# Altium schematic sheet telemetry_adc.SchDoc
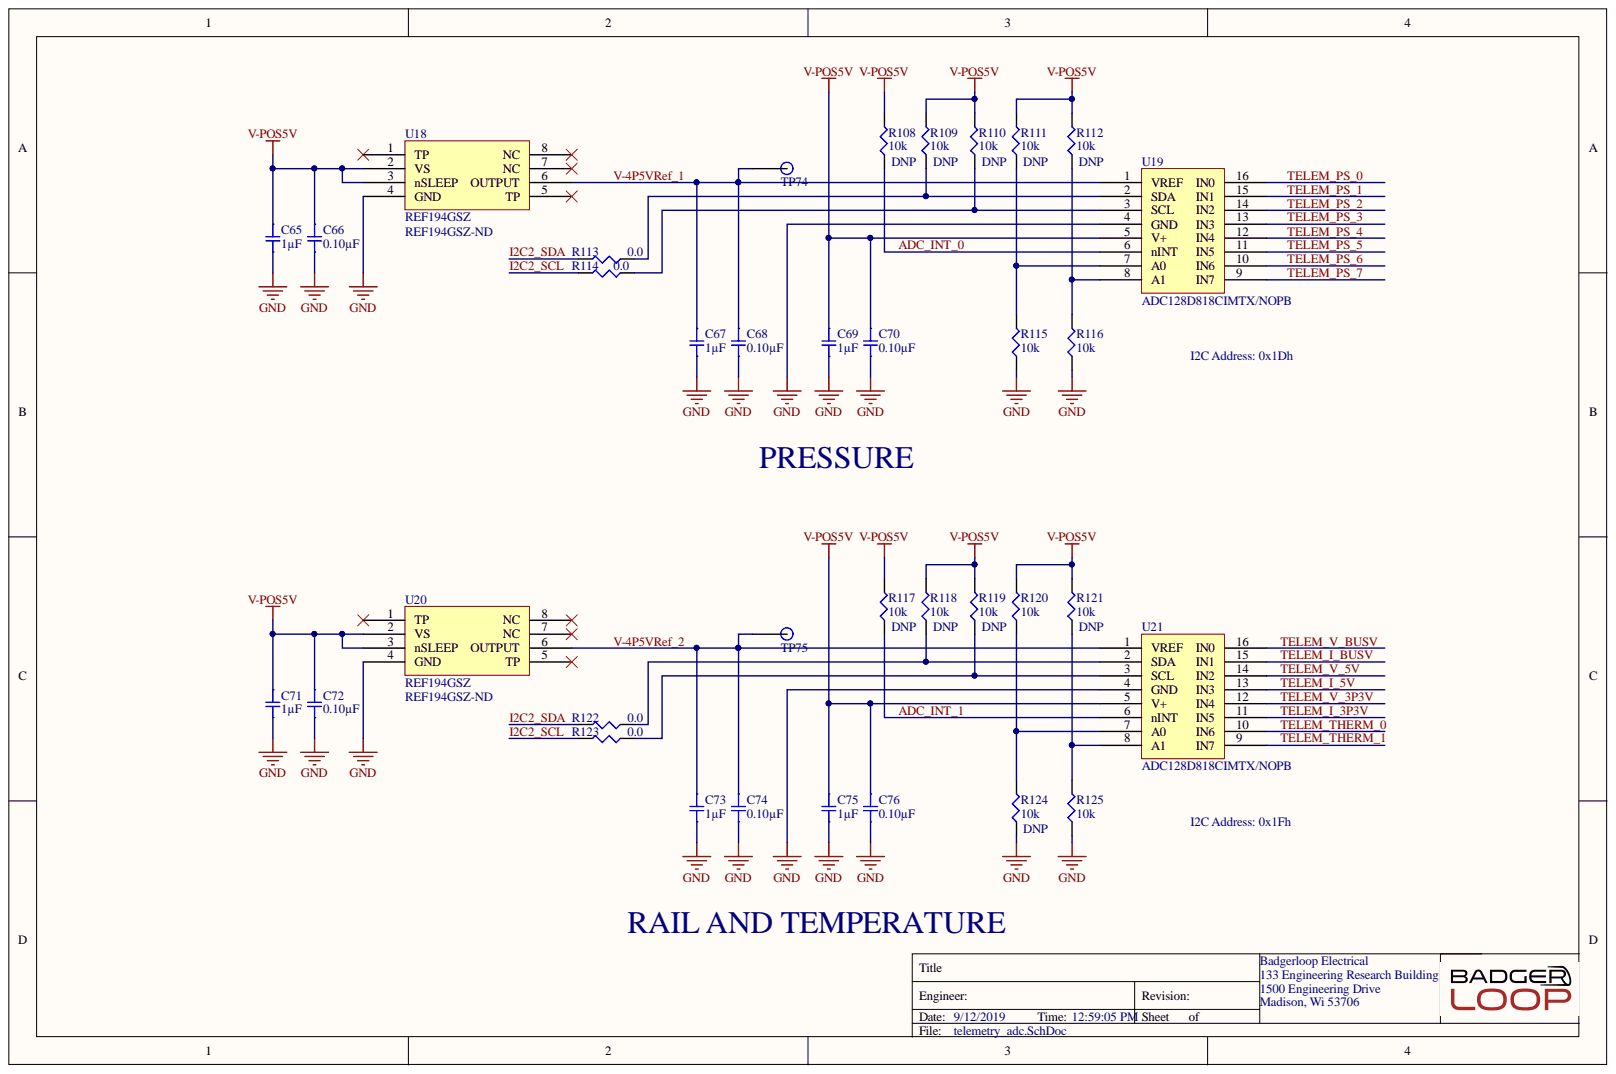
[diagram p0: telemetry_adc.SchDoc]

## Parts
C65  1µF  [SMD-0805C]
C66  0.10µF  [SMD-0805C]
C67  1µF  [SMD-0805C]
C68  0.10µF  [SMD-0805C]
C69  1µF  [SMD-0805C]
C70  0.10µF  [SMD-0805C]
C71  1µF  [SMD-0805C]
C72  0.10µF  [SMD-0805C]
C73  1µF  [SMD-0805C]
C74  0.10µF  [SMD-0805C]
C75  1µF  [SMD-0805C]
C76  0.10µF  [SMD-0805C]
R108  10k  [SMD-0805-RES]
R109  10k  [SMD-0805-RES]
R110  10k  [SMD-0805-RES]
R111  10k  [SMD-0805-RES]
R112  10k  [SMD-0805-RES]
R113  0.0  [SMD-0805-RES]
R114  0.0  [SMD-0805-RES]
R115  10k  [SMD-0805-RES]
R116  10k  [SMD-0805-RES]
R117  10k  [SMD-0805-RES]
R118  10k  [SMD-0805-RES]
R119  10k  [SMD-0805-RES]
R120  10k  [SMD-0805-RES]
R121  10k  [SMD-0805-RES]
R122  0.0  [SMD-0805-RES]
R123  0.0  [SMD-0805-RES]
R124  10k  [SMD-0805-RES]
R125  10k  [SMD-0805-RES]
TP74  TP  [Test Point]
TP75  TP  [Test Point]
U18  REF194GSZ  Supplier Part Number 1: REF194GSZ-ND  [SOP127P600X175-8N]
U19  ADC128D818CIMTX/NOPB
U20  REF194GSZ  Supplier Part Number 1: REF194GSZ-ND  [SOP127P600X175-8N]
U21  ADC128D818CIMTX/NOPB

## Nets
GND: C65.2 C66.2 C67.2 C68.2 C69.2 C70.2 C71.2 C72.2 C73.2 C74.2 C75.2 C76.2 R115.1 R116.1 R124.1 R125.1 U18.4(GND) U19.4(GND) U20.4(GND) U21.4(GND)
V-POS5V: C65.1 C66.1 C69.1 C70.1 C71.1 C72.1 C75.1 C76.1 R108.2 R109.2 R110.2 R111.2 R112.2 R117.2 R118.2 R119.2 R120.2 R121.2 U18.2(VS) U18.3(nSLEEP) U19.5(V+) U20.2(VS) U20.3(nSLEEP) U21.5(V+)
ADC_INT_0: R108.1 U19.6(nINT)
ADC_INT_1: R117.1 U21.6(nINT)
I2C2_SCL: R114.2 R123.2
I2C2_SDA: R113.2 R122.2
TELEM_I_3P3V: U21.11(IN5)
TELEM_I_5V: U21.13(IN3)
TELEM_I_BUSV: U21.15(IN1)
TELEM_PS_0: U19.16(IN0)
TELEM_PS_1: U19.15(IN1)
TELEM_PS_2: U19.14(IN2)
TELEM_PS_3: U19.13(IN3)
TELEM_PS_4: U19.12(IN4)
TELEM_PS_5: U19.11(IN5)
TELEM_PS_6: U19.10(IN6)
TELEM_PS_7: U19.9(IN7)
TELEM_THERM_0: U21.10(IN6)
TELEM_THERM_1: U21.9(IN7)
TELEM_V_3P3V: U21.12(IN4)
TELEM_V_5V: U21.14(IN2)
TELEM_V_BUSV: U21.16(IN0)
V-4P5VRef_1: C67.1 C68.1 TP74.1 U18.6(OUTPUT) U19.1(VREF)
V-4P5VRef_2: C73.1 C74.1 TP75.1 U20.6(OUTPUT) U21.1(VREF)
NetR109_1: R109.1 R113.1 U19.2(SDA)
NetR110_1: R110.1 R114.1 U19.3(SCL)
NetR111_1: R111.1 R115.2 U19.7(A0)
NetR112_1: R112.1 R116.2 U19.8(A1)
NetR118_1: R118.1 R122.1 U21.2(SDA)
NetR119_1: R119.1 R123.1 U21.3(SCL)
NetR120_1: R120.1 R124.2 U21.7(A0)
NetR121_1: R121.1 R125.2 U21.8(A1)

Not connected: U18 1(TP) 5(TP) 7(NC) 8(NC); U20 1(TP) 5(TP) 7(NC) 8(NC)

## Library data of the parts
C65 C67 C69 C71 C73 C75 = GRM21BR71H105KA12L: CAP CER 1UF 50V X7R 0805; Manufacturer: Murata Electronics North America; @ qty: 0; Minimum Quantity: 1; Tolerance: ±10%; Unit Price (USD): 0.25; Applications: General Purpose; Component Kind: Standard; Datasheets: http://www.murata.com/~/media/webrenewal/support/library/catalog/products/capacitor/mlcc/c02e.pdf; Digi-Key Part Number: 490-4736-1-ND; Factory Stock: 0; Features: -; Height - Seated (Max): -; Image: //media.digikey.com/Renders/Murata%20Renders/0805%20(2012%20Metric)%20.85mm.jpg; Lead Spacing: -; Lead Style: -; Library Name: capacitors.SchLib; Mounting Type: Surface Mount, MLCC; Operating Temperature: -55°C ~ 125°C; Package / Case: 0805 (2012 Metric); Packaging: Cut Tape (CT); Part Number: GRM21BR71H105KA12L; Part Status: Active; Quantity Available: 988032; Ratings: -; Series: GRM; Size / Dimension: 0.079" L x 0.049" W (2.00mm x 1.25mm); Temperature Coefficient: X7R; Thickness (Max): 0.053" (1.35mm); Value: 1µF; Voltage: 50V
C66 C68 C70 C72 C74 C76 = GCD21BR71H104KA01L: CAP CER 0.1UF 50V X7R 0805; Manufacturer: Murata Electronics North America; @ qty: 0; Minimum Quantity: 1; Tolerance: ±10%; Unit Price (USD): 0.24; Applications: Automotive; Component Kind: Standard; Datasheets: http://www.murata.com/~/media/webrenewal/support/library/catalog/products/capacitor/mlcc/c03e.ashx; Digi-Key Part Number: 490-11955-1-ND; Factory Stock: 0; Features: -; Height - Seated (Max): -; Image: //media.digikey.com/Renders/Murata%20Renders/0805%28GxM6%29.jpg; Lead Spacing: -; Lead Style: -; Library Name: capacitors.SchLib; Mounting Type: Surface Mount, MLCC; Operating Temperature: -55°C ~ 125°C; Package / Case: 0805 (2012 Metric); Packaging: Cut Tape (CT); Part Number: GCD21BR71H104KA01L; Part Status: Active; Quantity Available: 157; Ratings: AEC-Q200; Series: GCD; Size / Dimension: 0.079" L x 0.049" W (2.00mm x 1.25mm); Temperature Coefficient: X7R; Thickness (Max): 0.049" (1.25mm); Value: 0.10µF; Voltage: 50V
R108-R112 R115-R121 R124 R125 = ERJ-P06J103V: RES SMD 10K OHM 5% 1/2W 0805; Manufacturer: Panasonic Electronic Components; @ qty: 1500; Factory Stock: 0; Minimum Quantity: 1; Power (Watts): 0.5W, 1/2W; Temperature Coefficient: ±200ppm/°C; Unit Price (USD): 0.01839; Component Kind: Standard; Composition: Thick Film; Datasheets: https://industrial.panasonic.com/cdbs/www-data/pdf/RDA0000/AOA0000C243.pdf; Digi-Key Part Number: P10KADCT-ND; Failure Rate: -; Features: Automotive AEC-Q200, Pulse Withstanding; Height - Seated (Max): 0.028" (0.70mm); Image: //media.digikey.com/Renders/Panasonic%20Renders/ERJ-0805%20Pkg.jpg; Library Name: resistors.SchLib; Number of Terminations: 2; Operating Temperature: -55°C ~ 155°C; Package / Case: 0805 (2012 Metric); Packaging: Cut Tape (CT); Part Number: ERJ-P06J103V; Part Status: Active; Quantity Available: 413576; Series: ERJ; Size / Dimension: 0.079" L x 0.049" W (2.00mm x 1.25mm); Supplier Device Package: 0805; Tolerance: ±5%; Value: 10k
  R108-R112 R117-R119 R121 R124: DNP: DNP
R113 R114 R122 R123 = ERJ-6GEY0R00V: RES SMD 0.0 OHM JUMPER 1/8W 0805; Manufacturer: Panasonic Electronic Components; @ qty: 1500; Factory Stock: 0; Minimum Quantity: 1; Power (Watts): 0.125W, 1/8W; Unit Price (USD): 0.00544; Component Kind: Standard; Composition: Thick Film; Datasheets: http://industrial.panasonic.com/www-cgi/jvcr13pz.cgi?E+PZ+3+AOA0001+ERJ6GEY0R00V+7+WW; Digi-Key Part Number: P0.0ACT-ND; Failure Rate: -; Features: Automotive AEC-Q200; Height - Seated (Max): 0.028" (0.70mm); Image: //media.digikey.com/Renders/Panasonic%20Renders/ERJ-0805%20Pkg.jpg; Library Name: resistors.SchLib; Number of Terminations: 2; Operating Temperature: -55°C ~ 155°C; Package / Case: 0805 (2012 Metric); Packaging: Cut Tape (CT); Part Number: ERJ-6GEY0R00V; Part Status: Active; Quantity Available: 1597627; Series: ERJ; Size / Dimension: 0.079" L x 0.049" W (2.00mm x 1.25mm); Supplier Device Package: 0805; Temperature Coefficient: -; Tolerance: Jumper; Value: 0.0
TP74 TP75 = TP: SMD TEST POINT; Component Kind: Standard; Library Name: misc.SchLib
U18 U20 = REF194GSZ: IC VREF SERIES 4.5V 8SOIC; Manufacturer: Analog Devices; Max Input Voltage: 18V; Max Output Current: 30mA; Min Input Voltage: 4.75V; Min Output Voltage: 4.49V; Nominal Supply Current: 45uA; Number of Channels: 1; Quiescent Current: 15uA; Temperature Coefficient: 25ppm/°C; Case/Package: R; Component Kind: Standard; ComponentLink1Description: https://datasheet.ciiva.com/17219/ref19xseries-17219157.pdf?src-supplier=Avnet+Europe; ComponentLink1URL: https://datasheet.ciiva.com/17219/ref19xseries-17219157.pdf?src-supplier=Avnet+Europe; ComponentLink2Description: https://datasheet.ciiva.com/22666/1506113-22666153.pdf?src-supplier=Element14; ComponentLink2URL: https://datasheet.ciiva.com/22666/1506113-22666153.pdf?src-supplier=Element14; ComponentLink3Description: https://datasheet.ciiva.com/2005/ref19xseries-2005902.pdf?src-supplier=Digi-Key; ComponentLink3URL: https://datasheet.ciiva.com/2005/ref19xseries-2005902.pdf?src-supplier=Digi-Key; ComponentLink4Description: https://datasheet.ciiva.com/3235/ref19xseries-3235518.pdf?src-supplier=Avnet; ComponentLink4URL: https://datasheet.ciiva.com/3235/ref19xseries-3235518.pdf?src-supplier=Avnet; Height: 1.5mm; Lead Free: Contains Lead; Length: 5mm; Library Name: ic.SchLib; Manufacturer Part Number: REF194GSZ; Max Operating Temperature: 85°C; Max Output Voltage: 4.5V; Min Operating Temperature: -40°C; Number of Pins: 8; Operating Supply Current: 45uA; Output Current: 30mA; Output Voltage: 4.5V; Package Quantity: 98; Packaging: Rail/Tube; Pricing 1: 1=3.56000, 10=3.19700, 25=3.02240, 100=2.61940, 250=2.48512, 500=2.22988, 1000=1.88062, 2500=1.78659 (USD); Radiation Hardening: No; REACH SVHC: No SVHC; Reference Type: Series; Reference Voltage: 4.5V; RoHS: Yes; Stock 1: 359; Supplier 1: Digi-Key; Width: 4mm
U19 U21 = ADC128D818CIMTX/NOPB: Analog to Digital Converters - ADC; Manufacturer: TI National Semiconductor; Differential Nonlinearity: 1LSB; Integral Nonlinearity (INL): -2LSB; Interface: I2C; Max Power Dissipation: 33mW; Max Supply Voltage: 5.5V; Min Supply Voltage: 3V; Number of A/D Converters: 1; Number of Bits: 12; Number of Channels: 8; Number of Converters: 4; Polarity: Unipolar; Power Consumption: 2mW; Power Dissipation: 2.01mW; Resolution: 12b; Sampling Rate: 10000S/s; Supply Type: Analog,Digital,Single; Case/Package: TSSOP; Component Kind: Standard; ComponentLink1Description: https://datasheet.ciiva.com/14259/adc128d818-14259977.pdf?src-supplier=Avnet; ComponentLink1URL: https://datasheet.ciiva.com/14259/adc128d818-14259977.pdf?src-supplier=Avnet; Contact Plating: Tin,Matte; Lead Free: Lead Free; Library Name: ic.SchLib; Manufacturer Part Number: ADC128D818CIMTX/NOPB; Max Operating Temperature: 125°C; Min Operating Temperature: -40°C; Mount: Surface Mount; Number of Elements: 1; Number of Inputs: 8; Number of Pins: 16; Package Quantity: 2500; Packaging: Tape and Reel; Pricing 1: 1=4.68000, 10=4.20700, 100=3.44660, 500=2.93402, 1000=2.47447 (USD); Radiation Hardening: No; RoHS: Yes; Stock 1: 21177; Supplier 1: Digi-Key; Supplier Part Number 1: 296-41182-1-ND
